# Altium schematic sheet IO-LINK TRNASCEIVER.SchDoc
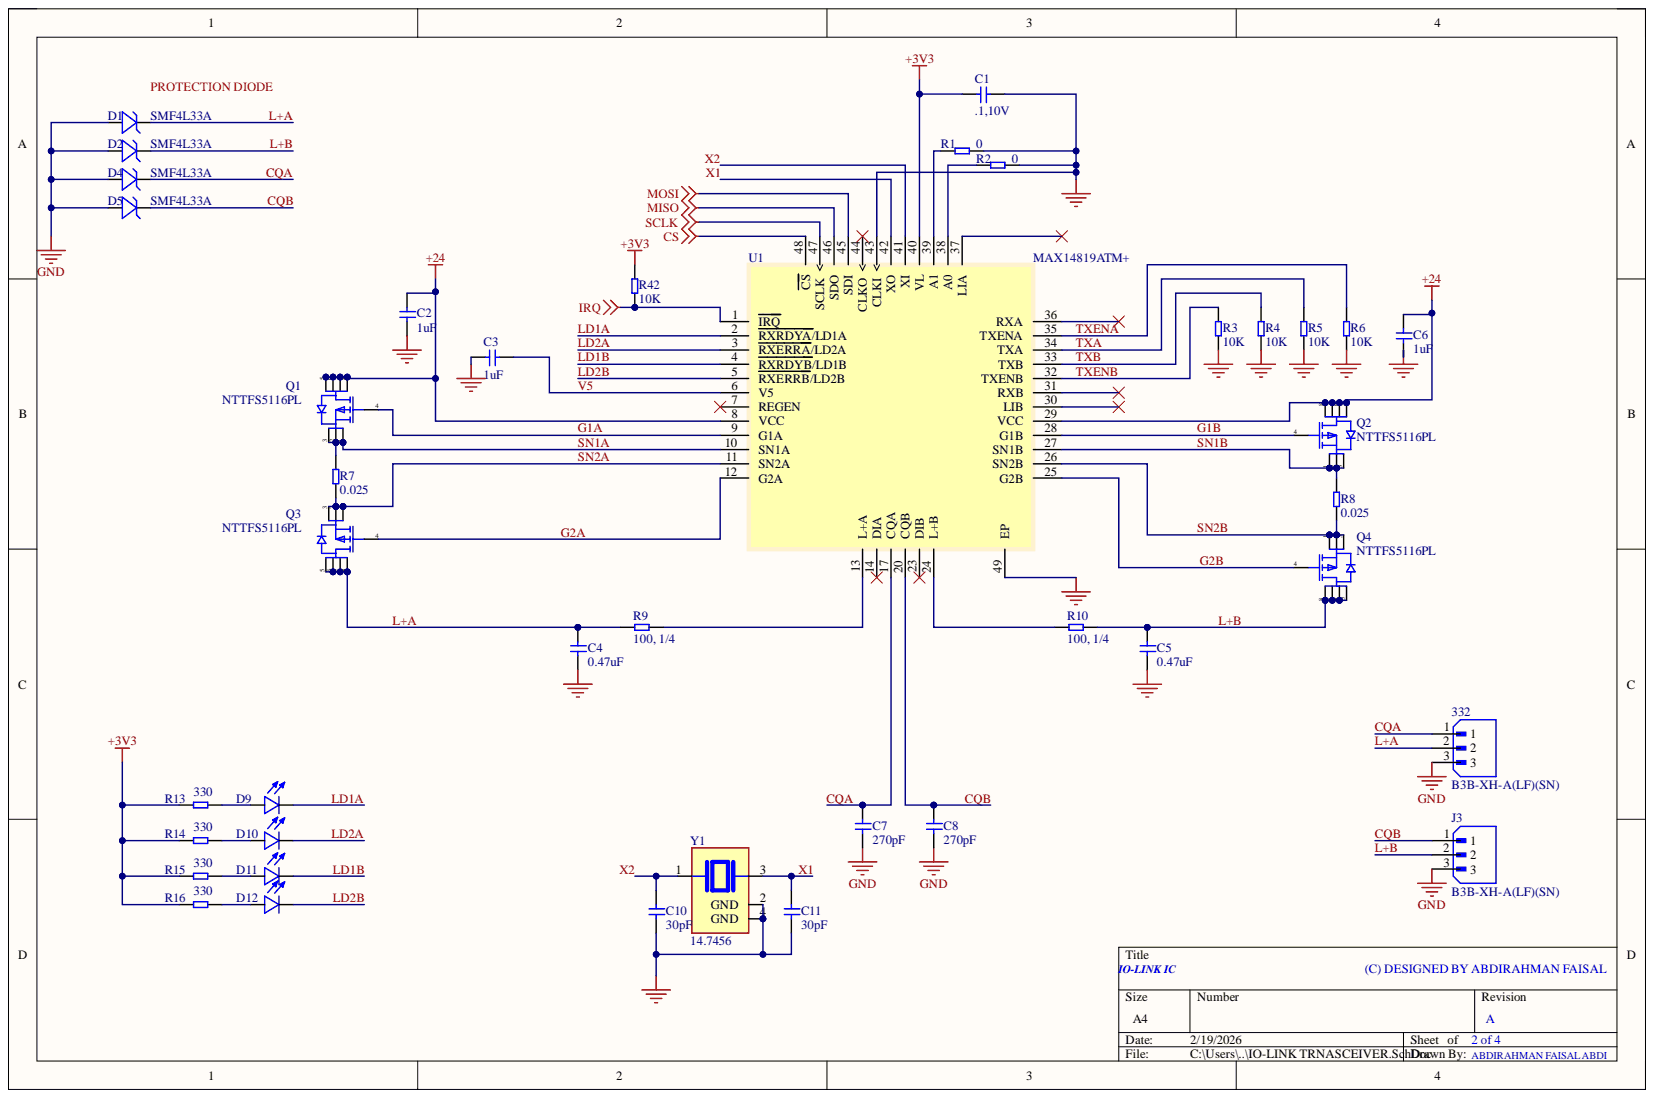
[diagram p0: IO-LINK TRNASCEIVER.SchDoc]

## Parts
332  B3B-XH-A(LF)(SN)  [JST_B3B-XH-A]
C1  .1,10V  [C0603]
C2  1uF  [0805 cap]
C3  1uF  [C0603]
C4  0.47uF  [C0603]
C5  0.47uF  [C0603]
C6  1uF  [0805 cap]
C7  270pF  [C0603]
C8  270pF  [C0603]
C10  30pF  [C0603]
C11  30pF  [C0603]
D1  SMF4L33A  [SODFL3718X108N]
D2  SMF4L33A  [SODFL3718X108N]
D4  SMF4L33A  [SODFL3718X108N]
D5  SMF4L33A  [SODFL3718X108N]
D9  RED  [LED_VLMS1300-GS08]  (value not drawn)
D10  RED  [LED_VLMS1300-GS08]  (value not drawn)
D11  RED  [LED_VLMS1300-GS08]  (value not drawn)
D12  RED  [LED_VLMS1300-GS08]  (value not drawn)
J3  B3B-XH-A(LF)(SN)  [JST_B3B-XH-A]
Q1  NTTFS5116PL  [ONSC-WDFN-8-511AB_V]
Q2  NTTFS5116PL  [ONSC-WDFN-8-511AB_V]
Q3  NTTFS5116PL  [ONSC-WDFN-8-511AB_V]
Q4  NTTFS5116PL  [ONSC-WDFN-8-511AB_V]
R1  0  [R0603]
R2  0  [R0603]
R3  10K  [R0603]
R4  10K  [R0603]
R5  10K  [R0603]
R6  10K  [R0603]
R7  0.025  [R0603]
R8  0.025  [R0603]
R9  100, 1/4  [R0603]
R10  100, 1/4  [R0603]
R13  330  [R0603]
R14  330  [R0603]
R15  330  [R0603]
R16  330  [R0603]
R42  10K  [R0603]
U1  MAX14819ATM+  [QFN50P700X700X80-49N]
Y1  14.7456  [XTAL_ABM8-14.7456MHz-12-D1X-T]

## Nets
+3V3: C1.1 R13.1 R14.1 R15.1 R16.1 R42.2 U1.40(VL)
+24: C2.2 C6.2 Q1.5 Q1.6 Q1.7 Q1.8 Q2.5 Q2.6 Q2.7 Q2.8 U1.8(VCC) U1.29(VCC)
CS: U1.48(CS)
GND: 332.3 C1.2 C2.1 C3.1 C4.1 C5.1 C6.1 C7.1 C8.1 C10.1 C11.1 D1.2 D2.2 D4.2 D5.2 J3.3 R1.2 R2.2 R3.1 R4.1 R5.1 R6.1 U1.43(CLKI) U1.49(EP) Y1.2(GND) Y1.4(GND)
IRQ: R42.1 U1.1(IRQ)
MISO: U1.46(SDO)
MOSI: U1.45(SDI)
SCLK: U1.47(SCLK)
CQA: 332.1 C7.2 D4.1 U1.17(CQA)
CQB: C8.2 D5.1 J3.1 U1.20(CQB)
G1A: Q1.4 U1.9(G1A)
G1B: Q2.4 U1.28(G1B)
G2A: Q3.4 U1.12(G2A)
G2B: Q4.4 U1.25(G2B)
L+A: 332.2 C4.2 D1.1 Q3.5 Q3.6 Q3.7 Q3.8 R9.1
L+B: C5.2 D2.1 J3.2 Q4.5 Q4.6 Q4.7 Q4.8 R10.2
LD1A: D9.C U1.2(RXRDYA/LD1A)
LD1B: D11.C U1.4(RXRDYB/LD1B)
LD2A: D10.C U1.3(RXERRA/LD2A)
LD2B: D12.C U1.5(RXERRB/LD2B)
SN1A: Q1.1 Q1.2 Q1.3 R7.2 U1.10(SN1A)
SN1B: Q2.1 Q2.2 Q2.3 R8.2 U1.27(SN1B)
SN2A: Q3.1 Q3.2 Q3.3 R7.1 U1.11(SN2A)
SN2B: Q4.1 Q4.2 Q4.3 R8.1 U1.26(SN2B)
TXA: R5.2 U1.34(TXA)
TXB: R4.2 U1.33(TXB)
TXENA: R6.2 U1.35(TXENA)
TXENB: R3.2 U1.32(TXENB)
V5: C3.2 U1.6(V5)
X1: C11.2 U1.42(XO) Y1.3
X2: C10.2 U1.41(XI) Y1.1
NetD9_A: D9.A R13.2
NetD10_A: D10.A R14.2
NetD11_A: D11.A R15.2
NetD12_A: D12.A R16.2
NetR1_1: R1.1 U1.39(A1)
NetR2_1: R2.1 U1.38(A0)
NetR9_2: R9.2 U1.13(L+A)
NetR10_1: R10.1 U1.24(L+B)

Not connected: U1 7(REGEN) 14(DIA) 23(DIB) 30(LIB) 31(RXB) 36(RXA) 37(LIA) 44(CLKO)

## Library data of the parts
332 J3 = B3B-XH-A(LF)(SN): CONN HEADER VERT 2POS 2.5MM; Manufacturer: JST; Contact Resistance: 20mR; Current Rating: 3A; Gender: Male; Insulation Resistance: 1GR; Max Voltage Rating (AC): 250V; Number of Contacts: 3; Wire/Cable Gauge: 22AWG; Category: Headers and Wire Housings; Color: Natural; Component Kind: Standard; ComponentLink1Description: https://datasheet.ciiva.com/11746/0900766b8082653f-11746520.pdf?src-supplier=Rs; ComponentLink1URL: https://datasheet.ciiva.com/11746/0900766b8082653f-11746520.pdf?src-supplier=Rs; ComponentLink2Description: https://datasheet.ciiva.com/26894/getdatasheetpartid-640434-26894454.pdf?src-supplier=Verical; ComponentLink2URL: https://datasheet.ciiva.com/26894/getdatasheetpartid-640434-26894454.pdf?src-supplier=Verical; ComponentLink3Description: https://datasheet.ciiva.com/26894/getdatasheetpartid-440399-26894778.pdf?src-supplier=Verical; ComponentLink3URL: https://datasheet.ciiva.com/26894/getdatasheetpartid-440399-26894778.pdf?src-supplier=Verical; Connector Type: Header; Contact Finish - Mating: TIN; Contact Material: Brass; Contact Plating: Tin,Lead,Copper; Contact Shape: Square; Contact Type: Male Pin; Depth: 5.75mm; Fastening Type: Detent; Flammability Rating: UL94 V-0; Housing Color: White; Housing Material: Polyamide; Insulation Color: Natural; Insulation Material: Nylon; LCSC part number: C144394; Lead Free: Lead Free; Lead Pitch: 2.5mm; Length: 9.9mm; Manufacturer Part Number: B3B-XH-A(LF)(SN); Max Current Rating: 3A; Max Operating Temperature: 85°C; Max Voltage Rating (DC): 250V; Min Operating Temperature: -25°C; Mount: Through Hole; Number of Positions: 3; Number of Rows: 1; Orientation: Straight; Packaging: Bulk; Pitch: 2.5mm; Pricing 1: 1=0.07, 400=0.068, 1250=0.0661, 4000=0.0643, 12500=0.0607 (USD); Reach SVHC Compliant: No; RoHS: Yes; Series: XH; Stock 1: 87879; Supplier 1: Future Electronics; Termination: Solder; Voltage Rating: 250V; Voltage Rating (AC): 250V; Weight: 28.349523g; Library Name: arfaisal.IntLib
C1 = CC0603KRX7R6BB104: 0.1 µF ±10% 10V Ceramic Capacitor X7R 0603; Manufacturer: Yageo Group; Value: 100nF; Case Code (Imperial): 0603; Case Code (Metric): 1608; Case/Package: 0603; Category: Ceramic Capacitors; China RoHS: Compliant; Component Kind: Standard; ComponentLink1Description: https://datasheet.octopart.com:443/CC0603KRX7R6BB104-Yageo-datasheet-177165144.pdf?src-supplier=IHS; ComponentLink1URL: https://datasheet.octopart.com:443/CC0603KRX7R6BB104-Yageo-datasheet-177165144.pdf?src-supplier=IHS; ComponentLink2Description: https://datasheet.octopart.com:443/CC0603KRX7R6BB104-Yageo-datasheet-82288757.pdf?src-supplier=Upverter; ComponentLink2URL: https://datasheet.octopart.com:443/CC0603KRX7R6BB104-Yageo-datasheet-82288757.pdf?src-supplier=Upverter; ComponentLink3Description: https://datasheet.octopart.com:443/CC0603KRX7R6BB104-Yageo-Group-datasheet-189192896.pdf?src-supplier=TME; ComponentLink3URL: https://datasheet.octopart.com:443/CC0603KRX7R6BB104-Yageo-Group-datasheet-189192896.pdf?src-supplier=TME; ComponentLink4Description: https://datasheet.octopart.com:443/CC0603KRX7R6BB104-Yageo-datasheet-182029707.pdf?src-supplier=Farnell; ComponentLink4URL: https://datasheet.octopart.com:443/CC0603KRX7R6BB104-Yageo-datasheet-182029707.pdf?src-supplier=Farnell; ComponentLink5Description: https://datasheet.octopart.com:443/CC0603KRX7R6BB104-Yageo-datasheet-147453679.pdf?src-supplier=Farnell; ComponentLink5URL: https://datasheet.octopart.com:443/CC0603KRX7R6BB104-Yageo-datasheet-147453679.pdf?src-supplier=Farnell; ComponentLink6Description: https://datasheet.octopart.com:443/CC0603KRX7R6BB104-Yageo-datasheet-151948686.pdf?src-supplier=Farnell; ComponentLink6URL: https://datasheet.octopart.com:443/CC0603KRX7R6BB104-Yageo-datasheet-151948686.pdf?src-supplier=Farnell; ComponentLink7Description: https://datasheet.octopart.com/CC0603KRX7R6BB104-Yageo-datasheet-10883670.pdf; ComponentLink7URL: https://datasheet.octopart.com/CC0603KRX7R6BB104-Yageo-datasheet-10883670.pdf; ComponentLink8Description: https://datasheet.octopart.com/CC0603KRX7R6BB104-Yageo-datasheet-12526321.pdf; ComponentLink8URL: https://datasheet.octopart.com/CC0603KRX7R6BB104-Yageo-datasheet-12526321.pdf; ComponentLink9Description: https://datasheet.octopart.com:443/CC0603KRX7R6BB104-Yageo-datasheet-166511530.pdf?src-supplier=Future+Electronics; ComponentLink9URL: https://datasheet.octopart.com:443/CC0603KRX7R6BB104-Yageo-datasheet-166511530.pdf?src-supplier=Future+Electronics; ComponentLink10Description: https://datasheet.octopart.com:443/CC0603KRX7R6BB104-Yageo-datasheet-137700082.pdf?src-supplier=Future+Electronics; ComponentLink10URL: https://datasheet.octopart.com:443/CC0603KRX7R6BB104-Yageo-datasheet-137700082.pdf?src-supplier=Future+Electronics; ComponentLink11Description: https://datasheet.octopart.com:443/CC0603KRX7R6BB104-Yageo-datasheet-137700084.pdf?src-supplier=Future+Electronics; ComponentLink11URL: https://datasheet.octopart.com:443/CC0603KRX7R6BB104-Yageo-datasheet-137700084.pdf?src-supplier=Future+Electronics; ComponentLink12Description: https://datasheet.octopart.com:443/CC0603KRX7R6BB104-Yageo-datasheet-27626582.pdf?src-supplier=Future+Electronics; ComponentLink12URL: https://datasheet.octopart.com:443/CC0603KRX7R6BB104-Yageo-datasheet-27626582.pdf?src-supplier=Future+Electronics; ComponentLink13Description: https://datasheet.octopart.com:443/CC0603KRX7R6BB104-Yageo-datasheet-15895757.pdf?src-supplier=Future+Electronics; ComponentLink13URL: https://datasheet.octopart.com:443/CC0603KRX7R6BB104-Yageo-datasheet-15895757.pdf?src-supplier=Future+Electronics; ComponentLink14Description: https://datasheet.octopart.com:443/CC0603KRX7R6BB104-Yageo-datasheet-10911834.pdf?src-supplier=Future+Electronics; ComponentLink14URL: https://datasheet.octopart.com:443/CC0603KRX7R6BB104-Yageo-datasheet-10911834.pdf?src-supplier=Future+Electronics; ComponentLink15Description: https://datasheet.octopart.com:443/CC0603KRX7R6BB104-Yageo-datasheet-8324442.pdf?src-supplier=Future+Electronics; ComponentLink15URL: https://datasheet.octopart.com:443/CC0603KRX7R6BB104-Yageo-datasheet-8324442.pdf?src-supplier=Future+Electronics; ComponentLink16Description: https://datasheet.octopart.com:443/CC0603KRX7R6BB104-Yageo-Group-datasheet-165992755.pdf?src-supplier=ODG+(Origin+Data+Global); ComponentLink16URL: https://datasheet.octopart.com:443/CC0603KRX7R6BB104-Yageo-Group-datasheet-165992755.pdf?src-supplier=ODG+(Origin+Data+Global); ComponentLink17Description: http://sigma.octopart.com:80/rohs_statement/Surface-Mount-Ceramic-Multilayer-Capacitors%3B-General-Purpose-%26-High-Capacitance-Class-2%2C-X7R-Yageo-family-124.pdf?src-supplier=Compliance+Statements; ComponentLink17URL: http://sigma.octopart.com:80/rohs_statement/Surface-Mount-Ceramic-Multilayer-Capacitors%3B-General-Purpose-%26-High-Capacitance-Class-2%2C-X7R-Yageo-family-124.pdf?src-supplier=Compliance+Statements; ComponentLink18Description: http://sigma.octopart.com:80/reach_statement/Surface-Mount-Ceramic-Multilayer-Capacitors%3B-General-Purpose-%26-High-Capacitance-Class-2%2C-X7R-Yageo-family-125.pdf?src-supplier=Compliance+Statements; ComponentLink18URL: http://sigma.octopart.com:80/reach_statement/Surface-Mount-Ceramic-Multilayer-Capacitors%3B-General-Purpose-%26-High-Capacitance-Class-2%2C-X7R-Yageo-family-125.pdf?src-supplier=Compliance+Statements; ComponentLink19Description: http://sigma.octopart.com:80/rohs_statement/Yageo-company-2.pdf?src-supplier=Compliance+Statements; ComponentLink19URL: http://sigma.octopart.com:80/rohs_statement/Yageo-company-2.pdf?src-supplier=Compliance+Statements; ComponentLink20Description: http://sigma.octopart.com:80/conflict_mineral_statement/Yageo-company-3.pdf?src-supplier=Compliance+Statements; ComponentLink20URL: http://sigma.octopart.com:80/conflict_mineral_statement/Yageo-company-3.pdf?src-supplier=Compliance+Statements; Composition: Ceramic; Depth: 800µm; Dielectric: X7R; Dielectric Material: Ceramic; Halogen Free: Halogen Free; Height: 800µm; Lead Free: Lead Free; Length: 1.6mm; Lifecycle Status: Production; Manufacturer Part Number: CC0603KRX7R6BB104; Material: Ceramic; Max Operating Temperature: 125°C; Min Operating Temperature: -55°C; Mounting Technology: Surface Mount; Number of Terminals: 2; Pricing: 1=0.11, 10=0.04, 50=0.04, 100=0.035, 1000=0.024, 10000=0.017 (USD); Radiation Hardening: No; REACH SVHC: Yes; RoHS: Compliant; Schedule B: 8532240020, 8532240020|8532240020|8532240020|8532240020|8532240020; Stock: 48844; Supplier: Mouser; Supplier Part Number: 603-CC603KRX7R6BB104; Termination: SMD/SMT; Thickness: 889µm; Tolerance: 10%; Voltage Rating: 10V; Voltage Rating (DC): 10V; Weight: 2.012816mg; Width: 800µm; Library Name: arfaisal.IntLib
C2 C6 = CC0805JKX7R9BB105: 0805 1 uF 50 V ±5 % Tolerance X7R Surface Mount Multilayer Ceramic Capacitor; Manufacturer: Yageo; Dielectric: 1511DielectricType; Material: 4241ConductorMaterial; Voltage Rating (DC): 50V; Category: Ceramic Capacitors; Component Kind: Standard; ComponentLink1Description: https://datasheet.octopart.com/CC0805JKX7R9BB105-Yageo-datasheet-137700082.pdf?src-supplier=Future+Electronics; ComponentLink1URL: https://datasheet.octopart.com/CC0805JKX7R9BB105-Yageo-datasheet-137700082.pdf?src-supplier=Future+Electronics; ComponentLink2Description: http://www.yageo.com/documents/recent/UPY-GPHC_X7R_6.3V-to-50V_18.pdf?src-supplier=Digikey; ComponentLink2URL: http://www.yageo.com/documents/recent/UPY-GPHC_X7R_6.3V-to-50V_18.pdf?src-supplier=Digikey; ComponentLink3Description: https://datasheet.octopart.com/CC0805JKX7R9BB105-Yageo-datasheet-169689539.pdf?src-supplier=Farnell; ComponentLink3URL: https://datasheet.octopart.com/CC0805JKX7R9BB105-Yageo-datasheet-169689539.pdf?src-supplier=Farnell; Halogen Free: 5694HalogenFree; Height: 1.45mm; LCSC part number: C541528; Lead Free: 5697LeadFree; Manufacturer Part Number: CC0805JKX7R9BB105; Pricing 1: 1=0.29, 10=0.168, 50=0.1218, 100=0.1077, 500=0.08414, 1000=0.07673 (USD); RoHS: Yes; Stock 1: 10290; Supplier 1: Digikey; Library Name: arfaisal.IntLib
C3 = CL10B105KP8NNNC: CAP CER 1UF 10V X7R 0603; Manufacturer: Samsung; Tolerance: 10%; Capacitance: 1uF; Case Code (Imperial): 0603; Case Code (Metric): 1608; Case/Package: 0603; Category: Ceramic Capacitors; Component Kind: Standard; ComponentLink1Description: https://datasheet.ciiva.com/25788/cl10b105kp8nnnc-25788304.pdf?src-supplier=Digikey; ComponentLink1URL: https://datasheet.ciiva.com/25788/cl10b105kp8nnnc-25788304.pdf?src-supplier=Digikey; ComponentLink2Description: https://datasheet.ciiva.com/16396/cl10b105kp8nnnc-16396970.pdf?src-supplier=Future+Electronics; ComponentLink2URL: https://datasheet.ciiva.com/16396/cl10b105kp8nnnc-16396970.pdf?src-supplier=Future+Electronics; ComponentLink3Description: https://datasheet.ciiva.com/26838/getdatasheetpartid-358410-26838426.pdf?src-supplier=Verical; ComponentLink3URL: https://datasheet.ciiva.com/26838/getdatasheetpartid-358410-26838426.pdf?src-supplier=Verical; Construction: Flat; Depth: 0.8mm; Dielectric: X7R; Height: 0.8mm; LCSC part number: C95843; Lead Free: Lead Free; Length: 1.6mm; Manufacturer Part Number: CL10B105KP8NNNC; Max Operating Temperature: 125°C; Min Operating Temperature: -55°C; Mount: Surface Mount; Package Quantity: 1; Packaging: Tape and Reel; Pricing 1: 1=0.08, 10=0.005 (USD); Radiation Hardening: No; RoHS: Yes; RoHS Compliant: Yes; Series: CL; Stock 1: 5099033; Supplier 1: Digikey; Thickness: 0.035inch; Voltage Rating: 10V; Voltage Rating (DC): 10V; Width: 0.031inch; Library Name: arfaisal.IntLib
C4 C5 = CC0603KRX7R9BB474: CAP CER 0.47UF 50V X7R 0603; Component Kind: Standard; Library Name: arfaisal.IntLib
C7 C8 = CC0603KRX7R9BB271: CAP CER 270PF 50V X7R 0603; Manufacturer: Yageo Group; Dielectric Material: Ceramic; Insulation Resistance: 100GΩ; Tolerance: 10%; Case Code (Imperial): 0603; Case Code (Metric): 1608; Case/Package: 0603; Category: Ceramic Capacitors; China RoHS: Compliant; Component Kind: Standard; ComponentLink1Description: https://datasheet.octopart.com:443/CC0603KRX7R9BB271-Yageo-datasheet-82288757.pdf?src-supplier=Upverter; ComponentLink1URL: https://datasheet.octopart.com:443/CC0603KRX7R9BB271-Yageo-datasheet-82288757.pdf?src-supplier=Upverter; ComponentLink2Description: https://datasheet.octopart.com:443/CC0603KRX7R9BB271-Yageo-datasheet-8324192.pdf?src-supplier=Future+Electronics; ComponentLink2URL: https://datasheet.octopart.com:443/CC0603KRX7R9BB271-Yageo-datasheet-8324192.pdf?src-supplier=Future+Electronics; ComponentLink3Description: https://datasheet.octopart.com:443/CC0603KRX7R9BB271-Yageo-datasheet-166511530.pdf?src-supplier=Future+Electronics; ComponentLink3URL: https://datasheet.octopart.com:443/CC0603KRX7R9BB271-Yageo-datasheet-166511530.pdf?src-supplier=Future+Electronics; ComponentLink4Description: https://datasheet.octopart.com:443/CC0603KRX7R9BB271-Yageo-datasheet-137700082.pdf?src-supplier=Future+Electronics; ComponentLink4URL: https://datasheet.octopart.com:443/CC0603KRX7R9BB271-Yageo-datasheet-137700082.pdf?src-supplier=Future+Electronics; ComponentLink5Description: https://datasheet.octopart.com:443/CC0603KRX7R9BB271-Yageo-datasheet-137700084.pdf?src-supplier=Future+Electronics; ComponentLink5URL: https://datasheet.octopart.com:443/CC0603KRX7R9BB271-Yageo-datasheet-137700084.pdf?src-supplier=Future+Electronics; ComponentLink6Description: https://datasheet.octopart.com:443/CC0603KRX7R9BB271-Yageo-datasheet-27626582.pdf?src-supplier=Future+Electronics; ComponentLink6URL: https://datasheet.octopart.com:443/CC0603KRX7R9BB271-Yageo-datasheet-27626582.pdf?src-supplier=Future+Electronics; ComponentLink7Description: https://datasheet.octopart.com:443/CC0603KRX7R9BB271-Yageo-datasheet-15895757.pdf?src-supplier=Future+Electronics; ComponentLink7URL: https://datasheet.octopart.com:443/CC0603KRX7R9BB271-Yageo-datasheet-15895757.pdf?src-supplier=Future+Electronics; ComponentLink8Description: https://datasheet.octopart.com:443/CC0603KRX7R9BB271-Yageo-datasheet-12526321.pdf?src-supplier=Future+Electronics; ComponentLink8URL: https://datasheet.octopart.com:443/CC0603KRX7R9BB271-Yageo-datasheet-12526321.pdf?src-supplier=Future+Electronics; ComponentLink9Description: https://datasheet.octopart.com/CC0603KRX7R9BB271-Yageo-datasheet-10883670.pdf; ComponentLink9URL: https://datasheet.octopart.com/CC0603KRX7R9BB271-Yageo-datasheet-10883670.pdf; ComponentLink10Description: https://datasheet.octopart.com:443/CC0603KRX7R9BB271-Yageo-datasheet-62336348.pdf?src-supplier=element14+APAC; ComponentLink10URL: https://datasheet.octopart.com:443/CC0603KRX7R9BB271-Yageo-datasheet-62336348.pdf?src-supplier=element14+APAC; ComponentLink11Description: https://datasheet.octopart.com:443/CC0603KRX7R9BB271-Yageo-datasheet-181968748.pdf?src-supplier=Farnell; ComponentLink11URL: https://datasheet.octopart.com:443/CC0603KRX7R9BB271-Yageo-datasheet-181968748.pdf?src-supplier=Farnell; ComponentLink12Description: https://datasheet.octopart.com:443/CC0603KRX7R9BB271-Yageo-datasheet-5301394.pdf?src-supplier=Newark; ComponentLink12URL: https://datasheet.octopart.com:443/CC0603KRX7R9BB271-Yageo-datasheet-5301394.pdf?src-supplier=Newark; ComponentLink13Description: https://datasheet.octopart.com:443/CC0603KRX7R9BB271-Yageo-datasheet-147453679.pdf?src-supplier=Newark; ComponentLink13URL: https://datasheet.octopart.com:443/CC0603KRX7R9BB271-Yageo-datasheet-147453679.pdf?src-supplier=Newark; ComponentLink14Description: https://datasheet.octopart.com:443/CC0603KRX7R9BB271-Yageo-Group-datasheet-165992755.pdf?src-supplier=ODG+(Origin+Data+Global); ComponentLink14URL: https://datasheet.octopart.com:443/CC0603KRX7R9BB271-Yageo-Group-datasheet-165992755.pdf?src-supplier=ODG+(Origin+Data+Global); ComponentLink15Description: https://datasheet.octopart.com:443/CC0603KRX7R9BB271-Yageo-datasheet-118396.pdf?src-supplier=DigiKey; ComponentLink15URL: https://datasheet.octopart.com:443/CC0603KRX7R9BB271-Yageo-datasheet-118396.pdf?src-supplier=DigiKey; ComponentLink16Description: http://sigma.octopart.com:80/conflict_mineral_statement/Yageo-company-3.pdf?src-supplier=Compliance+Statements; ComponentLink16URL: http://sigma.octopart.com:80/conflict_mineral_statement/Yageo-company-3.pdf?src-supplier=Compliance+Statements; ComponentLink17Description: http://sigma.octopart.com:80/rohs_statement/Yageo-company-2.pdf?src-supplier=Compliance+Statements; ComponentLink17URL: http://sigma.octopart.com:80/rohs_statement/Yageo-company-2.pdf?src-supplier=Compliance+Statements; Composition: Ceramic; Depth: 800µm; Dielectric: X7R; Halogen Free: Halogen Free; Height: 800µm; Lead Free: Lead Free; Length: 1.6mm; Lifecycle Status: Production; Manufacturer Part Number: CC0603KRX7R9BB271; Material: Ceramic; Max Operating Temperature: 125°C; Min Operating Temperature: -55°C; Mounting Technology: Surface Mount; Number of Terminals: 2; Pins: 2; Pricing: 1=0.1, 10=0.034, 50=0.023, 100=0.0196, 500=0.014, 1000=0.0123 (USD); Radiation Hardening: No; REACH SVHC: Yes; RoHS: Compliant; Schedule B: 8532240020, 8532240020|8532240020, 8532240020|8532240020|8532240020, 8532240020|8532240020|8532240020|8532240020; Stock: 24330; Supplier: DigiKey; Supplier Part Number: 311-1185-1-ND; Termination: SMD/SMT; Thickness: 889µm; Value: 270pF; Voltage Rating: 50V; Voltage Rating (AC): 50V; Voltage Rating (DC): 50V; Width: 800µm; Library Name: arfaisal.IntLib
C10 C11 = AC0603JRNPO9BN300: CAP CER 30PF 50V C0G/NPO 0603; Manufacturer: Yageo; Category: Ceramic Capacitors; Component Kind: Standard; LCSC part number: C2191217; Library Name: IO.SchLib; Manufacturer Part Number: AC0603JRNPO9BN300; Pricing 1: 1=0.11, 10=0.061, 50=0.0424, 100=0.035, 500=0.02706, 1000=0.02316 (USD); RoHS: No; Stock 1: 2686; Supplier 1: Digikey
D1 D2 D4 D5 = SMF4L33A: TVS DIODE 33VWM 53.3VC SOD123F; Component Kind: Standard; Library Name: arfaisal.IntLib
D9-D12 = VLMS1300-GS08: LED RED 0603 SMD; Manufacturer: Vishay Semiconductors; Dominant Wavelength: 631nm; Forward Current: 20mA; Forward Voltage: 2V; Lens Style: Rectangular,Clear; Luminous Intensity: 54mcd; Number of LEDs: 1; Power Rating: 75mW; Viewing Angle: 130°; Case Code (Imperial): 0603; Case Code (Metric): 1608; Case/Package: 0603; Category: LEDs; Color: Red; Component Kind: Standard; ComponentLink1Description: https://datasheet.ciiva.com/26322/vlmo1300-26322153.pdf?src-supplier=Element14; ComponentLink1URL: https://datasheet.ciiva.com/26322/vlmo1300-26322153.pdf?src-supplier=Element14; ComponentLink2Description: https://datasheet.ciiva.com/8028/vlmo1300-8028047.pdf?src-supplier=Element14; ComponentLink2URL: https://datasheet.ciiva.com/8028/vlmo1300-8028047.pdf?src-supplier=Element14; ComponentLink3Description: https://datasheet.ciiva.com/9333/vlmo1300-9333743.pdf?src-supplier=Avnet; ComponentLink3URL: https://datasheet.ciiva.com/9333/vlmo1300-9333743.pdf?src-supplier=Avnet; ComponentLink4Description: https://datasheet.ciiva.com/16510/vlmo1300-16510985.pdf?src-supplier=Future+Electronics; ComponentLink4URL: https://datasheet.ciiva.com/16510/vlmo1300-16510985.pdf?src-supplier=Future+Electronics; Height: 0.8mm; Illumination Color: Red; LCSC part number: C83242; Lead Free: Lead Free; Length: 1.6mm; Manufacturer Part Number: VLMS1300-GS08; Max Operating Temperature: 85°C; Min Operating Temperature: -35°C; Mount: Surface Mount; Package Quantity: 1; Packaging: Tape and Reel; Pricing 1: 1=0.21, 10=0.143, 100=0.0998, 500=0.07982, 1000=0.07322 (USD); Radiation Hardening: No; Reach SVHC Compliant: Unknown; RoHS: Yes; RoHS Compliant: Yes; Series: CHipLED; Stock 1: 42495; Supplier 1: Digikey; Test Current: 20mA; Wavelength: 631nm; Width: 0.8mm; Library Name: arfaisal.IntLib
Q1-Q4 = NTTFS5116PLTAG: MOSFET P-CH 60V 5.7A 8WDFN; Manufacturer: onsemi; Manufacturer Lifecycle Status: ACTIVE; Drain to Source Breakdown Voltage: -60V; Drain to Source Resistance: 52mΩ; Element Configuration: Single; Fall Time: 37ns; Gate to Source Voltage (Vgs): 20V; Input Capacitance: 1.258nF; Max Power Dissipation: 40W; Number of Elements: 1; Rise Time: 58ns; Threshold Voltage: -3V; Turn-Off Delay Time: 30ns; Turn-On Delay Time: 15ns; Value: 52MΩ; Case/Package: TO-252-3; Category: MOSFETs; China RoHS: Non-Compliant; Component Kind: Standard; ComponentLink1Description: https://datasheet.octopart.com:443/NTTFS5116PLTAG-onsemi-datasheet-180968484.pdf?src-supplier=IHS; ComponentLink1URL: https://datasheet.octopart.com:443/NTTFS5116PLTAG-onsemi-datasheet-180968484.pdf?src-supplier=IHS; ComponentLink2Description: https://datasheet.octopart.com:443/NTTFS5116PLTAG-ON-Semiconductor-datasheet-139013317.pdf?src-supplier=Master+Electronics; ComponentLink2URL: https://datasheet.octopart.com:443/NTTFS5116PLTAG-ON-Semiconductor-datasheet-139013317.pdf?src-supplier=Master+Electronics; ComponentLink3Description: https://datasheet.octopart.com:443/NTTFS5116PLTAG-ON-Semiconductor-datasheet-11552915.pdf?src-supplier=RS+(Formerly+Allied+Electronics); ComponentLink3URL: https://datasheet.octopart.com:443/NTTFS5116PLTAG-ON-Semiconductor-datasheet-11552915.pdf?src-supplier=RS+(Formerly+Allied+Electronics); ComponentLink4Description: https://datasheet.octopart.com:443/NTTFS5116PLTAG-onsemi-datasheet-182419065.pdf?src-supplier=Farnell; ComponentLink4URL: https://datasheet.octopart.com:443/NTTFS5116PLTAG-onsemi-datasheet-182419065.pdf?src-supplier=Farnell; ComponentLink5Description: http://sigma.octopart.com:80/150629679/rohs_statement/ON-Semiconductor-NTTFS5116PLTAG.pdf?src-supplier=Compliance+Statements; ComponentLink5URL: http://sigma.octopart.com:80/150629679/rohs_statement/ON-Semiconductor-NTTFS5116PLTAG.pdf?src-supplier=Compliance+Statements; ComponentLink6Description: http://sigma.octopart.com:80/reach_statement/ON-Semiconductor-company-79.pdf?src-supplier=Compliance+Statements; ComponentLink6URL: http://sigma.octopart.com:80/reach_statement/ON-Semiconductor-company-79.pdf?src-supplier=Compliance+Statements; ComponentLink7Description: https://octopart.com:443/opatz8j6/c1?t=iqzrp5L3WKp8UzxXKCcR1wXxSOWPj4SdpsnAtPOTAYmuzaa_wX0hft1G5ul4WWFM4a_FYQJAEDKJUjm4SzfgmvbOtOMHGlWcbKVWv1KyDncfo1_2EPMzSdvjgFg7iNiee-3B6pF2l-5fMDDtk2ag4zSTDaxuaJ97xED3mIKPjai0kqpppkBwayPLo1T00cSRMBZr07hFosto9bZfc_hanZTU2oUkflEfeyFcfTFAmu2FaDCu4XnuLVlKvOdaFSggev-hSlZ2P1NSjEPDmJ382hHcDw5W0SoidSZeV85L4qzh8wHegeedXnTV9ZpOcIsrEpS_F1xWkB_M_aGy9yVJvJLjZZK-kThc8HgZ6Qdc2dH8&src-supplier=Compliance+Statements; ComponentLink7URL: https://octopart.com:443/opatz8j6/c1?t=iqzrp5L3WKp8UzxXKCcR1wXxSOWPj4SdpsnAtPOTAYmuzaa_wX0hft1G5ul4WWFM4a_FYQJAEDKJUjm4SzfgmvbOtOMHGlWcbKVWv1KyDncfo1_2EPMzSdvjgFg7iNiee-3B6pF2l-5fMDDtk2ag4zSTDaxuaJ97xED3mIKPjai0kqpppkBwayPLo1T00cSRMBZr07hFosto9bZfc_hanZTU2oUkflEfeyFcfTFAmu2FaDCu4XnuLVlKvOdaFSggev-hSlZ2P1NSjEPDmJ382hHcDw5W0SoidSZeV85L4qzh8wHegeedXnTV9ZpOcIsrEpS_F1xWkB_M_aGy9yVJvJLjZZK-kThc8HgZ6Qdc2dH8&src-supplier=Compliance+Statements; ComponentLink8Description: https://octopart.com:443/opatz8j6/c1?t=sARY3N_yCyQYJx8cJ30ICDUBU5adujhShMmSPR__SIJGS6ASf_jOJSTPBLeTs9NHahAWijShm4vl6O7FqsNtwQdMBDFgpqjgSs-PF7JUPZUokaw_mNAdkCDgyybLqdK6IioHWuIZdHbKzbr9H58wT-nb_MNyNoQQtfbn52JAWYzD8p3gAxWTRrrvwQzzLbEqHJSLS3MCEVU4J6UplZMHUcsQ6a2E89sKEeGgUk_fDCgyWuJmXhvrprrgCbbe5ZqvoNN9b3f9ENr8RAFvgcUo_Fzy1HsjXWosOG0IkQI_GSn445Fl3Yby-SD8Q1IwtDo7S_NYupm-zsbB-96Nl6Rs-67UU3BCWHCGOj98M3YkLX8&src-supplier=Compliance+Statements; ComponentLink8URL: https://octopart.com:443/opatz8j6/c1?t=sARY3N_yCyQYJx8cJ30ICDUBU5adujhShMmSPR__SIJGS6ASf_jOJSTPBLeTs9NHahAWijShm4vl6O7FqsNtwQdMBDFgpqjgSs-PF7JUPZUokaw_mNAdkCDgyybLqdK6IioHWuIZdHbKzbr9H58wT-nb_MNyNoQQtfbn52JAWYzD8p3gAxWTRrrvwQzzLbEqHJSLS3MCEVU4J6UplZMHUcsQ6a2E89sKEeGgUk_fDCgyWuJmXhvrprrgCbbe5ZqvoNN9b3f9ENr8RAFvgcUo_Fzy1HsjXWosOG0IkQI_GSn445Fl3Yby-SD8Q1IwtDo7S_NYupm-zsbB-96Nl6Rs-67UU3BCWHCGOj98M3YkLX8&src-supplier=Compliance+Statements; Contact Plating: Tin; Continuous Drain Current (ID): 5.7A; Drain to Source Voltage (Vdss): 60V; Height: 750µm; Lead Free: Lead Free; Length: 3.15mm; Lifecycle Status: Production; Manufacturer Part Number: NTTFS5116PLTAG; Max Operating Temperature: 175°C; Min Breakdown Voltage: 60V; Min Operating Temperature: -55°C; Number of Terminals: 8; Packaging: Tape and Reel; Pins: 8; Power Dissipation: 40W; Pricing: 1500=0.402, 3000=0.339, 9000=0.33 (USD); Radiation Hardening: No; Rds On Max: 52mΩ; REACH SVHC: No; RoHS: Compliant; Schedule B: 8541290080; Stock: 13500; Supplier: Arrow Electronics; Supplier Part Number: NTTFS5116PLTAG; Width: 3.15mm; Library Name: ARFEISAL.SchLib
R1 R2 = AF0603FR-070RL: Fixed Resistor, Metal Glaze/thick Film, 0.1W, 0.05ohm, Surface Mount, 0603; Manufacturer: Yageo Group; Power Dissipation: 100mW; Value: 50mΩ; Case/Package: 0603; Category: Chip SMD Resistors; China RoHS: Non-Compliant; Component Kind: Standard; ComponentLink1Description: https://datasheet.octopart.com:443/AF0603FR-070RL-Yageo-Group-datasheet-182189395.pdf?src-supplier=IHS; ComponentLink1URL: https://datasheet.octopart.com:443/AF0603FR-070RL-Yageo-Group-datasheet-182189395.pdf?src-supplier=IHS; ComponentLink2Description: https://datasheet.octopart.com:443/AF0603FR-070RL-Yageo-datasheet-13314862.pdf?src-supplier=IHS; ComponentLink2URL: https://datasheet.octopart.com:443/AF0603FR-070RL-Yageo-datasheet-13314862.pdf?src-supplier=IHS; ComponentLink3Description: https://datasheet.octopart.com/AF0603FR-070RL-Yageo-datasheet-68296209.pdf; ComponentLink3URL: https://datasheet.octopart.com/AF0603FR-070RL-Yageo-datasheet-68296209.pdf; ComponentLink4Description: http://sigma.octopart.com:80/conflict_mineral_statement/Yageo-company-3.pdf?src-supplier=Compliance+Statements; ComponentLink4URL: http://sigma.octopart.com:80/conflict_mineral_statement/Yageo-company-3.pdf?src-supplier=Compliance+Statements; ComponentLink5Description: http://sigma.octopart.com:80/rohs_statement/Yageo-company-2.pdf?src-supplier=Compliance+Statements; ComponentLink5URL: http://sigma.octopart.com:80/rohs_statement/Yageo-company-2.pdf?src-supplier=Compliance+Statements; Height: 450µm; Lifecycle Status: Production; Manufacturer Part Number: AF0603FR-070RL; Max Operating Temperature: 155°C; Min Operating Temperature: -55°C; Mounting Technology: Surface Mount; Number of Terminals: 2; Packaging: Tape & Reel; Pricing: 1=0.1, 10=0.03, 25=0.022, 50=0.0178, 100=0.0146, 250=0.01144, 500=0.00964, 1000=0.00821 (USD); REACH SVHC: Yes; RoHS: Compliant; Stock: 3666; Supplier: DigiKey; Supplier Part Number: 13-AF0603FR-070RLCT-ND; Width: 800µm; Library Name: arfaisal.IntLib
R3-R6 R42 = AC0201FR-0710KL: SMD Chip Resistor, 10 kOhm, ± 1%, 50 mW, 0201 [0603 Metric], Thick Film, General Purpose; Manufacturer: Yageo; Max Power Dissipation: 50mW; Resistance: 10kR; Temperature Coefficient: 200ppm/°C; Case Code (Imperial): 0201; Case Code (Metric): 0603; Case/Package: 0201; Category: Chip SMD Resistors; Component Kind: Standard; ComponentLink1Description: https://datasheet.octopart.com/AC0201FR-0710KL-Yageo-datasheet-166720450.pdf?src-supplier=Future+Electronics; ComponentLink1URL: https://datasheet.octopart.com/AC0201FR-0710KL-Yageo-datasheet-166720450.pdf?src-supplier=Future+Electronics; ComponentLink2Description: http://www.yageo.com/NewPortal/yageodocoutput?fileName=/pdf/R-Chip/PYu-AC_51_RoHS_L_6.pdf&src-supplier=Digikey; ComponentLink2URL: http://www.yageo.com/NewPortal/yageodocoutput?fileName=/pdf/R-Chip/PYu-AC_51_RoHS_L_6.pdf&src-supplier=Digikey; Features: Moisture Resistant,Automotive AEC-Q200; Height: 0.01inch; LCSC part number: C144833; Length: 0.024inch; Manufacturer Part Number: AC0201FR-0710KL; Max Operating Temperature: 155°C; Min Operating Temperature: -55°C; Number of Terminations: 2; Packaging: Cut Tape; Pricing 1: 1=0.1, 10=0.012, 100=0.0092, 1000=0.00714, 2500=0.00612 (USD); RoHS: Yes; RoHS Compliant: Yes; Series: AC; Stock 1: 68849; Supplier 1: Digikey; Tolerance: 1%; Width: 0.012inch; Library Name: arfaisal.IntLib
R7 R8 = PS0306FRF7W0R025L: Fixed Resistor, 0.33W, 0.025ohm, 0.0908V, 1% +/-Tol, 100ppm/Cel, Surface Mount, 0306; Manufacturer: Yageo Group; Power Dissipation: 330mW; Power Rating: 500mW; Temperature Coefficient: 100ppm/°C; Value: 25mΩ; Working Voltage: 90.8mV; Case/Package: 0306; Category: Chip SMD Resistors; Component Kind: Standard; ComponentLink1Description: https://datasheet.octopart.com:443/PS0306FRF7W0R025L-Yageo-datasheet-175860741.pdf?src-supplier=IHS; ComponentLink1URL: https://datasheet.octopart.com:443/PS0306FRF7W0R025L-Yageo-datasheet-175860741.pdf?src-supplier=IHS; ComponentLink2Description: http://sigma.octopart.com:80/conflict_mineral_statement/Yageo-company-3.pdf?src-supplier=Compliance+Statements; ComponentLink2URL: http://sigma.octopart.com:80/conflict_mineral_statement/Yageo-company-3.pdf?src-supplier=Compliance+Statements; ComponentLink3Description: http://sigma.octopart.com:80/rohs_statement/Yageo-company-2.pdf?src-supplier=Compliance+Statements; ComponentLink3URL: http://sigma.octopart.com:80/rohs_statement/Yageo-company-2.pdf?src-supplier=Compliance+Statements; Composition: Metal Film; Height: 500µm; Lifecycle Status: Production; Manufacturer Part Number: PS0306FRF7W0R025L; Max Operating Temperature: 125°C; Min Operating Temperature: -55°C; Mounting Technology: Surface Mount; Number of Terminals: 4; Pricing: 1=0.96, 10=0.625, 25=0.5336, 50=0.4768, 100=0.4282, 250=0.37492, 500=0.34162, 1000=0.31329 (USD); REACH SVHC: Yes; RoHS: Compliant; Stock: 5005; Supplier: DigiKey; Supplier Part Number: YAG6114CT-ND; Tolerance: 1%; Width: 1.6mm; Library Name: arfaisal.IntLib
R9 R10 = SR0603FR-7T100RL (2): RES 100 OHM 1% 1/4W 0603; Manufacturer: Yageo Group; Power Dissipation: 250mW; Temperature Coefficient: 100ppm/°C; Value: 100Ω; Voltage Rating: 75V; Working Voltage: 150V; Case/Package: 0603; Category: Chip SMD Resistors; China RoHS: Non-Compliant; Component Kind: Standard; ComponentLink1Description: https://datasheet.octopart.com:443/SR0603FR-7T100RL-Yageo-datasheet-176402240.pdf?src-supplier=element14+APAC; ComponentLink1URL: https://datasheet.octopart.com:443/SR0603FR-7T100RL-Yageo-datasheet-176402240.pdf?src-supplier=element14+APAC; ComponentLink2Description: https://datasheet.octopart.com:443/SR0603FR-7T100RL-Yageo-datasheet-119732217.pdf?src-supplier=Upverter; ComponentLink2URL: https://datasheet.octopart.com:443/SR0603FR-7T100RL-Yageo-datasheet-119732217.pdf?src-supplier=Upverter; ComponentLink3Description: https://datasheet.octopart.com:443/SR0603FR-7T100RL-Yageo-datasheet-155675517.pdf?src-supplier=Future+Electronics; ComponentLink3URL: https://datasheet.octopart.com:443/SR0603FR-7T100RL-Yageo-datasheet-155675517.pdf?src-supplier=Future+Electronics; ComponentLink4Description: https://datasheet.octopart.com:443/SR0603FR-7T100RL-Yageo-datasheet-137701088.pdf?src-supplier=Future+Electronics; ComponentLink4URL: https://datasheet.octopart.com:443/SR0603FR-7T100RL-Yageo-datasheet-137701088.pdf?src-supplier=Future+Electronics; ComponentLink5Description: https://datasheet.octopart.com/SR0603FR-7T100RL-Yageo-datasheet-11047080.pdf; ComponentLink5URL: https://datasheet.octopart.com/SR0603FR-7T100RL-Yageo-datasheet-11047080.pdf; ComponentLink6Description: http://sigma.octopart.com:80/conflict_mineral_statement/Yageo-company-3.pdf?src-supplier=Compliance+Statements; ComponentLink6URL: http://sigma.octopart.com:80/conflict_mineral_statement/Yageo-company-3.pdf?src-supplier=Compliance+Statements; ComponentLink7Description: http://sigma.octopart.com:80/rohs_statement/Yageo-company-2.pdf?src-supplier=Compliance+Statements; ComponentLink7URL: http://sigma.octopart.com:80/rohs_statement/Yageo-company-2.pdf?src-supplier=Compliance+Statements; Composition: Thick Film; Height: 450µm; Lifecycle Status: Production; Manufacturer Part Number: SR0603FR-7T100RL; Max Operating Temperature: 155°C; Min Operating Temperature: -55°C; Mounting Technology: Surface Mount; Number of Terminals: 2; Packaging: Tape & Reel; Power Rating: 250mW; Pricing: 1=0.19 (USD); REACH SVHC: Yes; RoHS: Compliant; Schedule B: 8533210045, 8533210045|8533210045|8533210045|8533210045|8533210045; Stock: 5682; Supplier: DigiKey; Supplier Part Number: YAG5822CT-ND; Tolerance: 1%; Width: 800µm
  R9: Library Name: arfaisal.IntLib
  R10: Library Name: ARFEISAL.SchLib
R13-R16 = RC0603FR-07330RL: RES 330 OHM 1% 1/10W 0603; Manufacturer: Yageo; Capacitance: 47uF; ESR (Equivalent Series Resistance): 800mR; Max Power Dissipation: 100mW; Resistance: 330R; Temperature Coefficient: 100ppm/°C; Voltage Rating: 50V; Case Code (Imperial): 0603; Case Code (Metric): 1608; Case/Package: 0603; Category: Chip SMD Resistors; Component Kind: Standard; Depth: 0.8mm; Features: Moisture Resistant; Height: 0.45mm; LCSC part number: C105881; Lead Free: 5697LeadFree; Length: 1.6mm; Manufacturer Part Number: RC0603FR-07330RL; Max Operating Temperature: 155°C; Min Operating Temperature: -55°C; Number of Pins: 2; Number of Terminations: 2; Package Quantity: 1; Packaging: Tape and Reel; Power Rating: 100mW; Pricing 1: 1=0.1, 10=0.009, 100=0.0082, 1000=0.00612, 2500=0.00461 (USD); Reach SVHC Compliant: No; Resistance Tolerance: 1%; Resistor Type: Thick Film; RoHS: Yes; RoHS Compliant: Yes; Series: RC; Size Code: 0603; Stock 1: 425796; Supplier 1: Digikey; Technology: THICK FILM; Termination: SMD/SMT; Tolerance: 1%; Voltage Rating (AC): 50V; Voltage Rating (DC): 50V; Width: 0.8mm; Library Name: arfaisal.IntLib
U1 = MAX14819ATM+: Transceiver IO-Link 48-TQFN (7x7); Manufacturer: Analog Devices; Manufacturer Lifecycle Status: PRODUCTION; Category: Buffers, Drivers and Transceivers; Component Kind: Standard; ComponentLink1Description: https://octopart.com:443/opatz8j6/c1?t=VtvA4XsbD7Sd6MlWSfS3c8BLgfeGPCChcVj_sFalJsX9NXsWZkcRcL6YgJF6BfEX3zCwxKZ3hS8qowmai8mKPr2rACpb8ApfuRmAvGBhvP-PJ9SQe63ixSGbcJ_6h2uAo_w-bMvElS2G0wOq6KGd9HVMqhlmx1OZoSDV2WL419hmKRoq6QV-TQKoxrL_syY3_knPECT4YR-iXhN-euwX1JunUxBa3BUpB2pssLLTB1B1XJOxBOmDWohLid1GtnbO914UYwxacnPEXMt4cmRINknTOJyLTxFrP_pJW65d7evXl0ZFWMRGLERW9g&src-supplier=Analog+Devices; ComponentLink1URL: https://octopart.com:443/opatz8j6/c1?t=VtvA4XsbD7Sd6MlWSfS3c8BLgfeGPCChcVj_sFalJsX9NXsWZkcRcL6YgJF6BfEX3zCwxKZ3hS8qowmai8mKPr2rACpb8ApfuRmAvGBhvP-PJ9SQe63ixSGbcJ_6h2uAo_w-bMvElS2G0wOq6KGd9HVMqhlmx1OZoSDV2WL419hmKRoq6QV-TQKoxrL_syY3_knPECT4YR-iXhN-euwX1JunUxBa3BUpB2pssLLTB1B1XJOxBOmDWohLid1GtnbO914UYwxacnPEXMt4cmRINknTOJyLTxFrP_pJW65d7evXl0ZFWMRGLERW9g&src-supplier=Analog+Devices; ComponentLink2Description: https://datasheet.octopart.com:443/MAX14819ATM%2B-Analog-Devices-datasheet-140089871.pdf?src-supplier=Ciiva; ComponentLink2URL: https://datasheet.octopart.com:443/MAX14819ATM%2B-Analog-Devices-datasheet-140089871.pdf?src-supplier=Ciiva; ComponentLink3Description: https://datasheet.octopart.com:443/MAX14819ATM%2B-Analog-Devices-datasheet-82522110.pdf?src-supplier=Newark; ComponentLink3URL: https://datasheet.octopart.com:443/MAX14819ATM%2B-Analog-Devices-datasheet-82522110.pdf?src-supplier=Newark; ComponentLink4Description: https://datasheet.octopart.com:443/MAX14819ATM%2B-Maxim-Integrated-datasheet-159004612.pdf?src-supplier=Augswan; ComponentLink4URL: https://datasheet.octopart.com:443/MAX14819ATM%2B-Maxim-Integrated-datasheet-159004612.pdf?src-supplier=Augswan; ComponentLink5Description: https://sigma.octopart.com:443/138896894/technical_drawing/Maxim-Integrated-MAX14819ATM%2B.pdf?src-supplier=ShanghaiCT; ComponentLink5URL: https://sigma.octopart.com:443/138896894/technical_drawing/Maxim-Integrated-MAX14819ATM%2B.pdf?src-supplier=ShanghaiCT; ComponentLink6Description: http://sigma.octopart.com:80/conflict_mineral_statement/Analog-Devices-company-33.pdf?src-supplier=Compliance+Statements; ComponentLink6URL: http://sigma.octopart.com:80/conflict_mineral_statement/Analog-Devices-company-33.pdf?src-supplier=Compliance+Statements; ComponentLink7Description: http://sigma.octopart.com:80/reach_statement/Maxim-Integrated-company-67.pdf?src-supplier=Compliance+Statements; ComponentLink7URL: http://sigma.octopart.com:80/reach_statement/Maxim-Integrated-company-67.pdf?src-supplier=Compliance+Statements; ComponentLink8Description: http://sigma.octopart.com:80/rohs_statement/Maxim-Integrated-company-66.pdf?src-supplier=Compliance+Statements; ComponentLink8URL: http://sigma.octopart.com:80/rohs_statement/Maxim-Integrated-company-66.pdf?src-supplier=Compliance+Statements; ComponentLink9Description: http://sigma.octopart.com:80/conflict_mineral_statement/Maxim-Integrated-company-65.pdf?src-supplier=Compliance+Statements; ComponentLink9URL: http://sigma.octopart.com:80/conflict_mineral_statement/Maxim-Integrated-company-65.pdf?src-supplier=Compliance+Statements; ComponentLink10Description: https://octopart.com:443/opatz8j6/c1?t=hb-Ohu_HaBWL840vroc0AcVVmSmKdeT1dtresGej75F7Ai5g7KWOcN8iulRhKTVToWqeG_ksbmz5j_XM-0ggf_fQklB1fmEBILJNGt7MFPLbt5rsoqeVkpzgCa6m9diSNXidGjLzsSSW3zW4_whmEeFz6VvzSx2iayMUi_aOPOOR3uv3brd3gheH60dr7cspS_3m1x1tImK-boZOFwqrQdqZ8ndgKZtsRAIn0I3rC7TynwAVJHqTO6hz8T0YBIE9o8-Z6UA-VERAneF0_rQPQMwuANfxZKnVcg0_l3Yjimuhit93YD79XxdEzg&src-supplier=Compliance+Statements; ComponentLink10URL: https://octopart.com:443/opatz8j6/c1?t=hb-Ohu_HaBWL840vroc0AcVVmSmKdeT1dtresGej75F7Ai5g7KWOcN8iulRhKTVToWqeG_ksbmz5j_XM-0ggf_fQklB1fmEBILJNGt7MFPLbt5rsoqeVkpzgCa6m9diSNXidGjLzsSSW3zW4_whmEeFz6VvzSx2iayMUi_aOPOOR3uv3brd3gheH60dr7cspS_3m1x1tImK-boZOFwqrQdqZ8ndgKZtsRAIn0I3rC7TynwAVJHqTO6hz8T0YBIE9o8-Z6UA-VERAneF0_rQPQMwuANfxZKnVcg0_l3Yjimuhit93YD79XxdEzg&src-supplier=Compliance+Statements; Lifecycle Status: Production; Manufacturer Part Number: MAX14819ATM+; Pins: 48; Pricing: 1=17, 10=13.494, 25=12.6184, 100=11.6568, 260=11.18112, 520=10.90785 (USD); RoHS: Compliant; Schedule B: 8542390000|8542390000|8542390000|8542390000|8542390000|8542390000|8542390000|8542390000|8542390000|8542390000|8542390000|8542390000|8542390000|8542390000|8542390000|8542390000|8542390000|8542390000|8542390000|8542390000|8542390000|8542390000|8542390000|85; Stock: 786; Supplier: DigiKey; Supplier Part Number: MAX14819ATM+-ND; Library Name: arfaisal.IntLib
Y1 = ABM8-14.7456MHZ-12-D1X-T: CRYSTAL 14.7456MHZ 12PF SMD; Manufacturer: Abracon; ESR: 120Ω; Frequency Stability: 20ppm; Frequency Tolerance: 0.001%; Category: Crystals; China RoHS: Compliant; Component Kind: Standard; ComponentLink1Description: https://datasheet.octopart.com:443/ABM8-14.7456MHZ-12-D1X-T-Abracon-datasheet-103059652.pdf?src-supplier=IHS; ComponentLink1URL: https://datasheet.octopart.com:443/ABM8-14.7456MHZ-12-D1X-T-Abracon-datasheet-103059652.pdf?src-supplier=IHS; ComponentLink2Description: https://datasheet.octopart.com:443/ABM8-14.7456MHZ-12-D1X-T-Abracon-datasheet-154833172.pdf?src-supplier=Master+Electronics; ComponentLink2URL: https://datasheet.octopart.com:443/ABM8-14.7456MHZ-12-D1X-T-Abracon-datasheet-154833172.pdf?src-supplier=Master+Electronics; ComponentLink3Description: https://sigma.octopart.com:443/141159868/technical_drawing/Abracon-ABM8-14.7456MHZ-12-D1X-T.pdf?src-supplier=Abracon+SCT; ComponentLink3URL: https://sigma.octopart.com:443/141159868/technical_drawing/Abracon-ABM8-14.7456MHZ-12-D1X-T.pdf?src-supplier=Abracon+SCT; ComponentLink4Description: http://sigma.octopart.com:80/conflict_mineral_statement/Abracon-company-27.pdf?src-supplier=Compliance+Statements; ComponentLink4URL: http://sigma.octopart.com:80/conflict_mineral_statement/Abracon-company-27.pdf?src-supplier=Compliance+Statements; ESR (Equivalent Series Resistance): 120Ω; Frequency: 14.7456MHz; Height: 800µm; Length: 3.2mm; Lifecycle Status: Production; Load Capacitance: 12pF; Manufacturer Part Number: ABM8-14.7456MHZ-12-D1X-T; Max Operating Temperature: 85°C; Min Operating Temperature: -40°C; Mounting Technology: Surface Mount; Pricing: 1=0.43, 10=0.375, 25=0.3544, 50=0.3398, 100=0.3257, 250=0.30804, 500=0.29528 (USD); REACH SVHC: Yes; RoHS: Compliant; Schedule B: 8541600080; Stock: 7042; Supplier: DigiKey; Supplier Part Number: 535-14966-1-ND; Tolerance: 0.001%; Width: 2.5mm; Library Name: arfaisal.IntLib
